# Altium schematic sheet Sheet_Rs485_v1.SchDoc(Sheet_Rs485_v1)
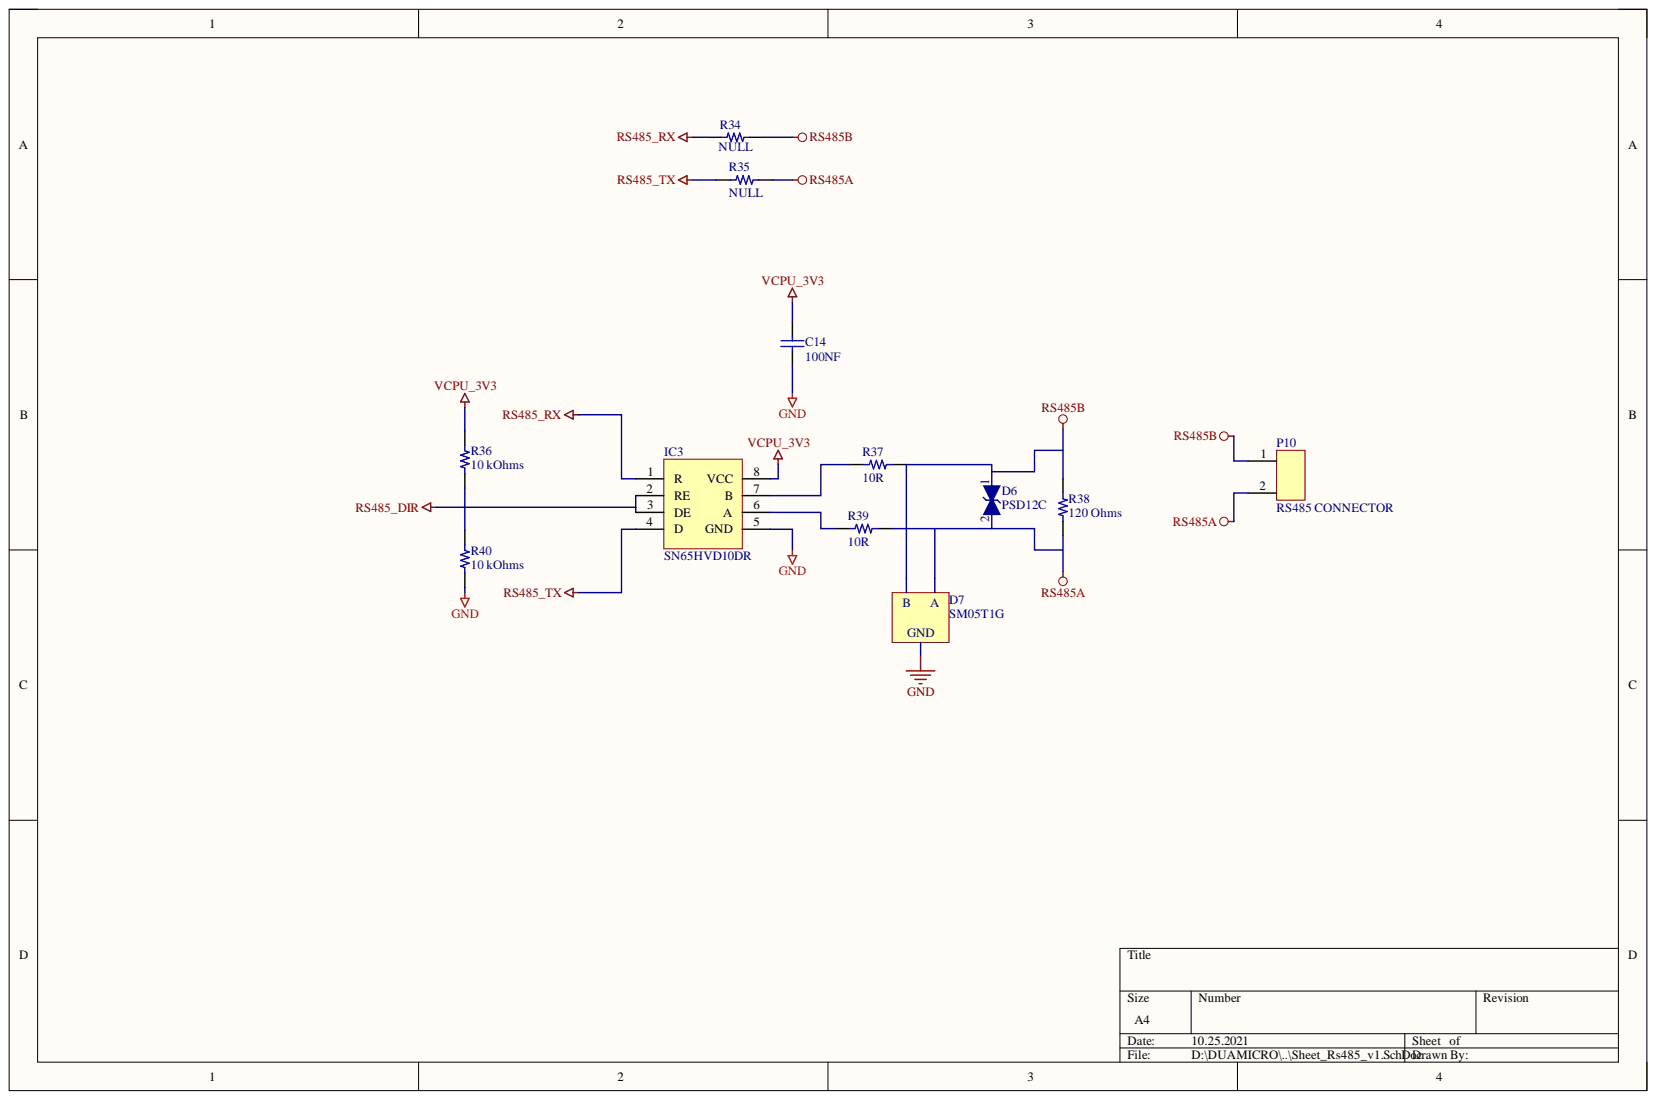
[diagram p0: Sheet_Rs485_v1.SchDoc]

## Parts
C14  100NF  [CAP 0603_1608]
D6  PSD12C  [SOD-323]
D7  SM05T1G  [SOT23]
IC3  SN65HVD10DR  [SM/SOIC8]
P10  RS485 CONNECTOR  [KLE2-3.50]
R34  NULL  [RES 0603/1608]
R35  NULL  [RES 0603/1608]
R36  10 kOhms  [RES 0603/1608]
R37  10R  [RES 0603/1608]
R38  120 Ohms  [RES 0603/1608]
R39  10R  [RES 0603/1608]
R40  10 kOhms  [RES 0603/1608]

## Nets
GND: C14.1 D7.C IC3.5(GND) R40.1
RS485_DIR: IC3.2(RE) IC3.3(DE) R36.1 R40.2
RS485_RX: IC3.1(R) R34.2
RS485_TX: IC3.4(D) R35.2
RS485A: D6.2 D7.B P10.2 R35.1 R38.1 R39.2
RS485B: D6.1 D7.E P10.1 R34.1 R37.2 R38.2
VCPU_3V3: C14.2 IC3.8(VCC) R36.2
NetR37_1: IC3.7(B) R37.1
NetR39_1: IC3.6(A) R39.1

## Library data of the parts
C14 = CAP CER 100NF 16V X7R 0603: Manufacturer: Samsung Electro-Mechanics; Part Number: CL10B104KO8NNNC; TemperatureRange: -55°C ~ 125°C; Tolerance: ±10%; Type: General Purpose; Component Kind: Standard; ComponentLink1Description: Datasheet; ComponentLink1URL: http://www.samsungsem.com/kr/support/product-search/mlcc/__icsFiles/afieldfile/2016/08/18/S_CL10B104KO8NNNC.pdf; ComponentLink2Description: Digi-Key listing; ComponentLink2URL: https://www.digikey.com/product-detail/en/samsung-electro-mechanics/CL10B104KO8NNNC/1276-1005-1-ND/3889091; Description: CAP CER 100NF 16V X7R 0603; Device Package: 0603 (1608 Metric); Price: $0.10000; Supplier 1: Digi-Key; Supplier Part Number 1: 1276-1005-1-ND; Value: 100NF; Voltage: 16V; Library Name: DualMicrolib.SchLib
D7 = PSM712: Component Kind: Standard; Library Name: Assan Shematic.SchLib
IC3 = MAX485: Component Kind: Standard; Library Name: Schlib (ASSAN).SchLib
P10 = con2: KF2EDGR-Y-3.5-2P-1G; Component Kind: Standard; Library Name: SchlibTMS.SchLib
R34 R35 = Resistor_NULL_0603: RES SMD NULL OHM JUMPER 1/10W 0603; Manufacturer: Panasonic Electronic Components; PowerRating: 0.1W, 1/10W; TemperatureRange: -55C ~ 155C; Component Kind: Standard; ComponentLink1Description: Datasheet; ComponentLink1URL: https://industrial.panasonic.com/ww/products/resistors/chip-resistors/general-purpose-chip-resistors/thick-film-chip-resistors/ERJ3GEY0R00V; ComponentLink2Description: Digi-Key Listing; ComponentLink2URL: https://www.digikey.com/product-detail/en/panasonic-electronic-components/ERJ-3GEY0R00V/P0.0GCT-ND/134711; Device Package: 0603 (1608 Metric); Price: $0.10000/min1; TemperatureCoefficient: -; Tolerance: Jumper; Value: NULL; Library Name: DualMicrolib.SchLib
R36 R40 = Resistor_10K_0603_5%: RES SMD 10K OHM 5% 1/16W 0603; Manufacturer: Stackpole Electronics; Part Number: RMCF0603JG10K0; PowerRating: 0.1W, 1/10W; TemperatureCoefficient: ±100ppm/°C; TemperatureRange: -55°C ~ 125°C; Component Kind: Standard; ComponentLink1Description: Datasheet; ComponentLink1URL: http://www.seielect.com/catalog/sei-packaging.pdf; ComponentLink2Description: Digi-Key Listing; ComponentLink2URL: https://www.digikey.com/en/products/detail/stackpole-electronics-inc/rmcf0603jg10k0/1712133; Device Package: 0603 (1608 Metric); Price: $0.1000/min1; Supplier 1: DigiKey; Supplier 2: Digi-Key; Supplier Part Number 1: RMCF0603JG10K0; Supplier Part Number 2: RMCF0603JG10K0CT-ND; Tolerance: ±5%; Value: 10 kOhms; Library Name: DualMicrolib.SchLib
R37 R39 = (no symbol name): CRGCQ 0603 10R 1%; Manufacturer: TE Connectivity Passive Product; Part Number: CRGCQ0603F10R; PowerRating: 0.1W, 1/10W; TemperatureCoefficient: ±400ppm/°C; TemperatureRange: -55°C ~ 155°C; Component Kind: Standard; ComponentLink1Description: Datasheet; ComponentLink1URL: https://www.te.com/commerce/DocumentDelivery/DDEController?Action=srchrtrv&DocNm=1773204-3&DocType=DS&DocLang=English; ComponentLink2Description: Digi-Key Listing; ComponentLink2URL: https://www.digikey.com/product-detail/en/te-connectivity-passive-product/CRGCQ0603J10R/A130079CT-ND/8577911; Device Package: 0603 (1608 Metric); Price: $0.10000/min1; Supplier 1: Digi-Key; Supplier Part Number 1: A129664TR-ND; Tolerance: ±1%; Value: 10R; Library Name: DualMicrolib.SchLib
R38 = (no symbol name): RES 120 OHM 5% 1/10W 0603; Manufacturer: Stackpole Electronics Inc; Part Number: RMCF0603JT120R; Power (Watts): 0.1W, 1/10W; Temperature Coefficient: ±200ppm/°C; Component Kind: Standard; ComponentLink1Description: Datasheet; ComponentLink1URL: https://www.seielect.com/catalog/sei-rmcf_rmcp.pdf; ComponentLink2Description: DigiKey Link; ComponentLink2URL: http://digikey.com/product-detail/en/stackpole-electronics-inc/RMCF0603JT120R/RMCF0603JT120RCT-ND/1943157; Composition: Thick Film; Device Package: 0603 (1608 Metric); Features: Automotive AEC-Q200; Height - Seated (Max): 0.022" (0.55mm); LastUpdated: 2.09.2020 01:10:22; Lifecycle Status: Active; Minimum Order: 1; Number of Terminations: 2; Operating Temperature: -55°C ~ 155°C; Package / Case: 0603 (1608 Metric); Packaging: CutTape; Part Status: Active; PartId: 5957; Price: 0.10; Ratings: AEC-Q200; Resistance: 120 Ohms; Series: RMCF; Size / Dimension: 0.061" L x 0.031" W (1.55mm x 0.80mm); Supplier 1: DigiKey; Supplier Device Package: 0603; Supplier Part Number 1: RMCF0603JT120RCT-ND; Tolerance: ±5%; Value: 120 Ohms; Library Name: DualMicrolib.SchLib
D6 = PSD12C: Component Kind: Standard; Library Name: Assan Shematic.SchLib
